# Altium schematic sheet EP40-FR-RR.SchDoc
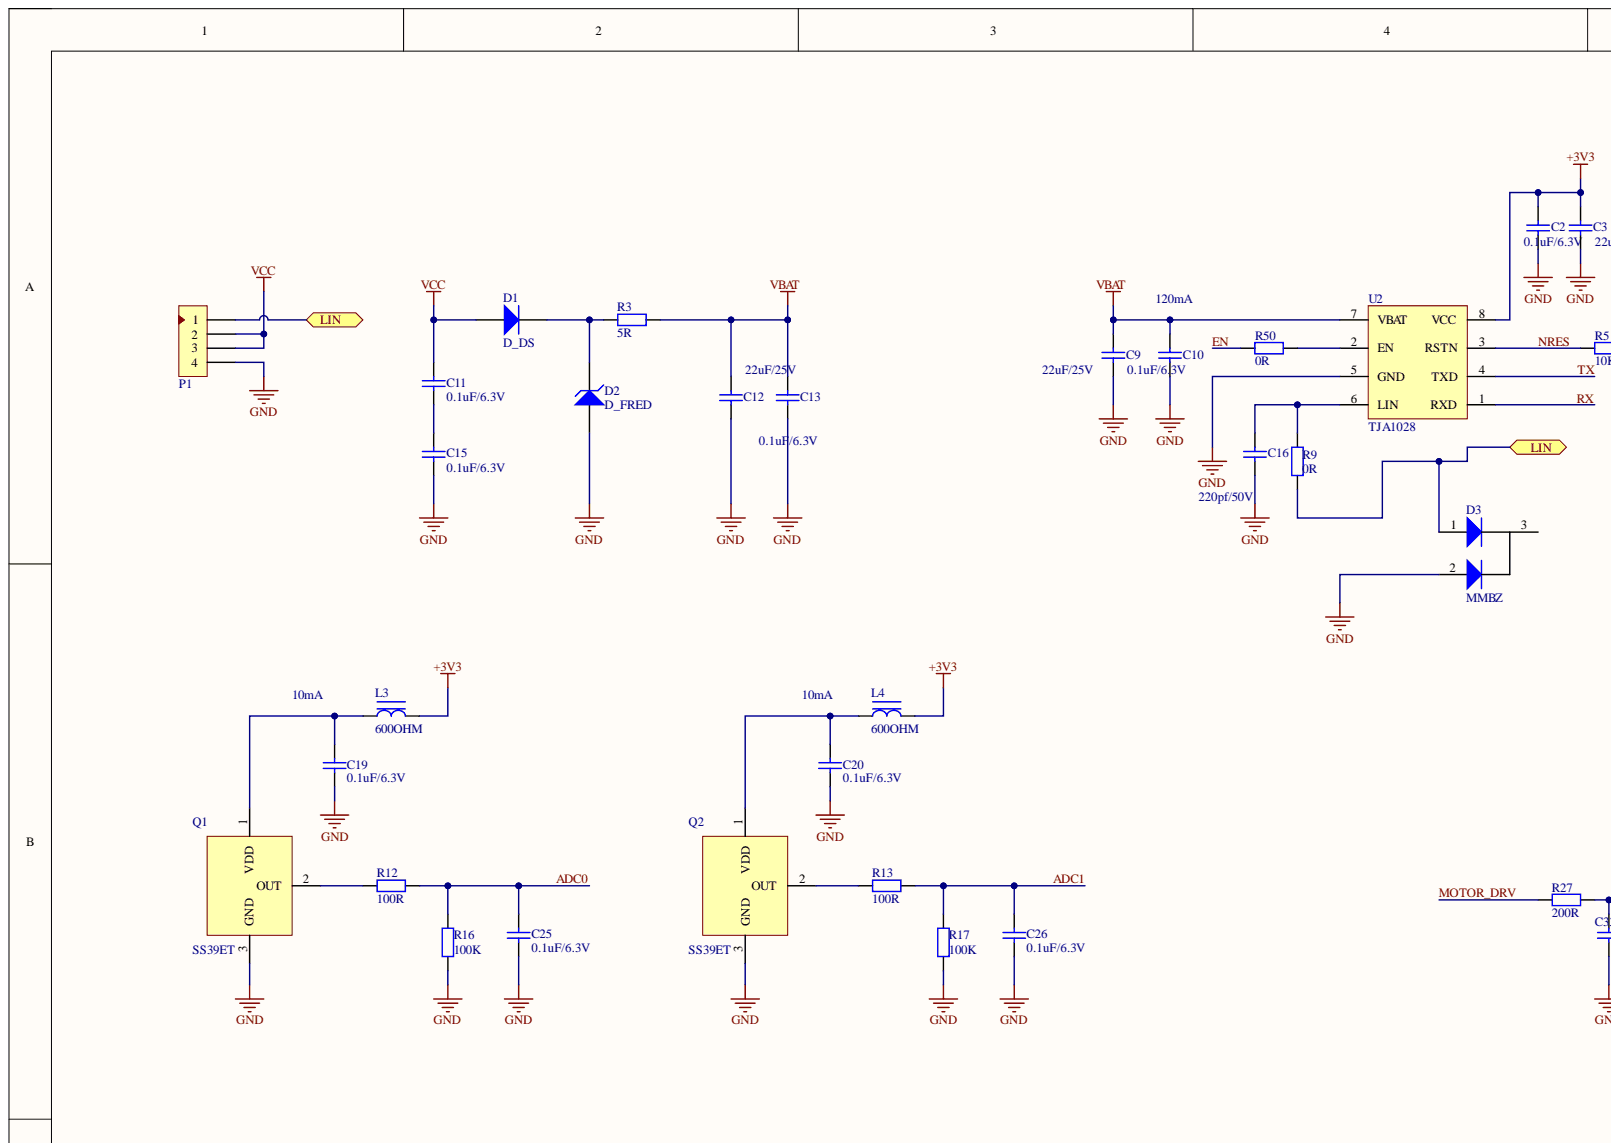
[diagram p0: EP40-FR-RR.SchDoc, top left]
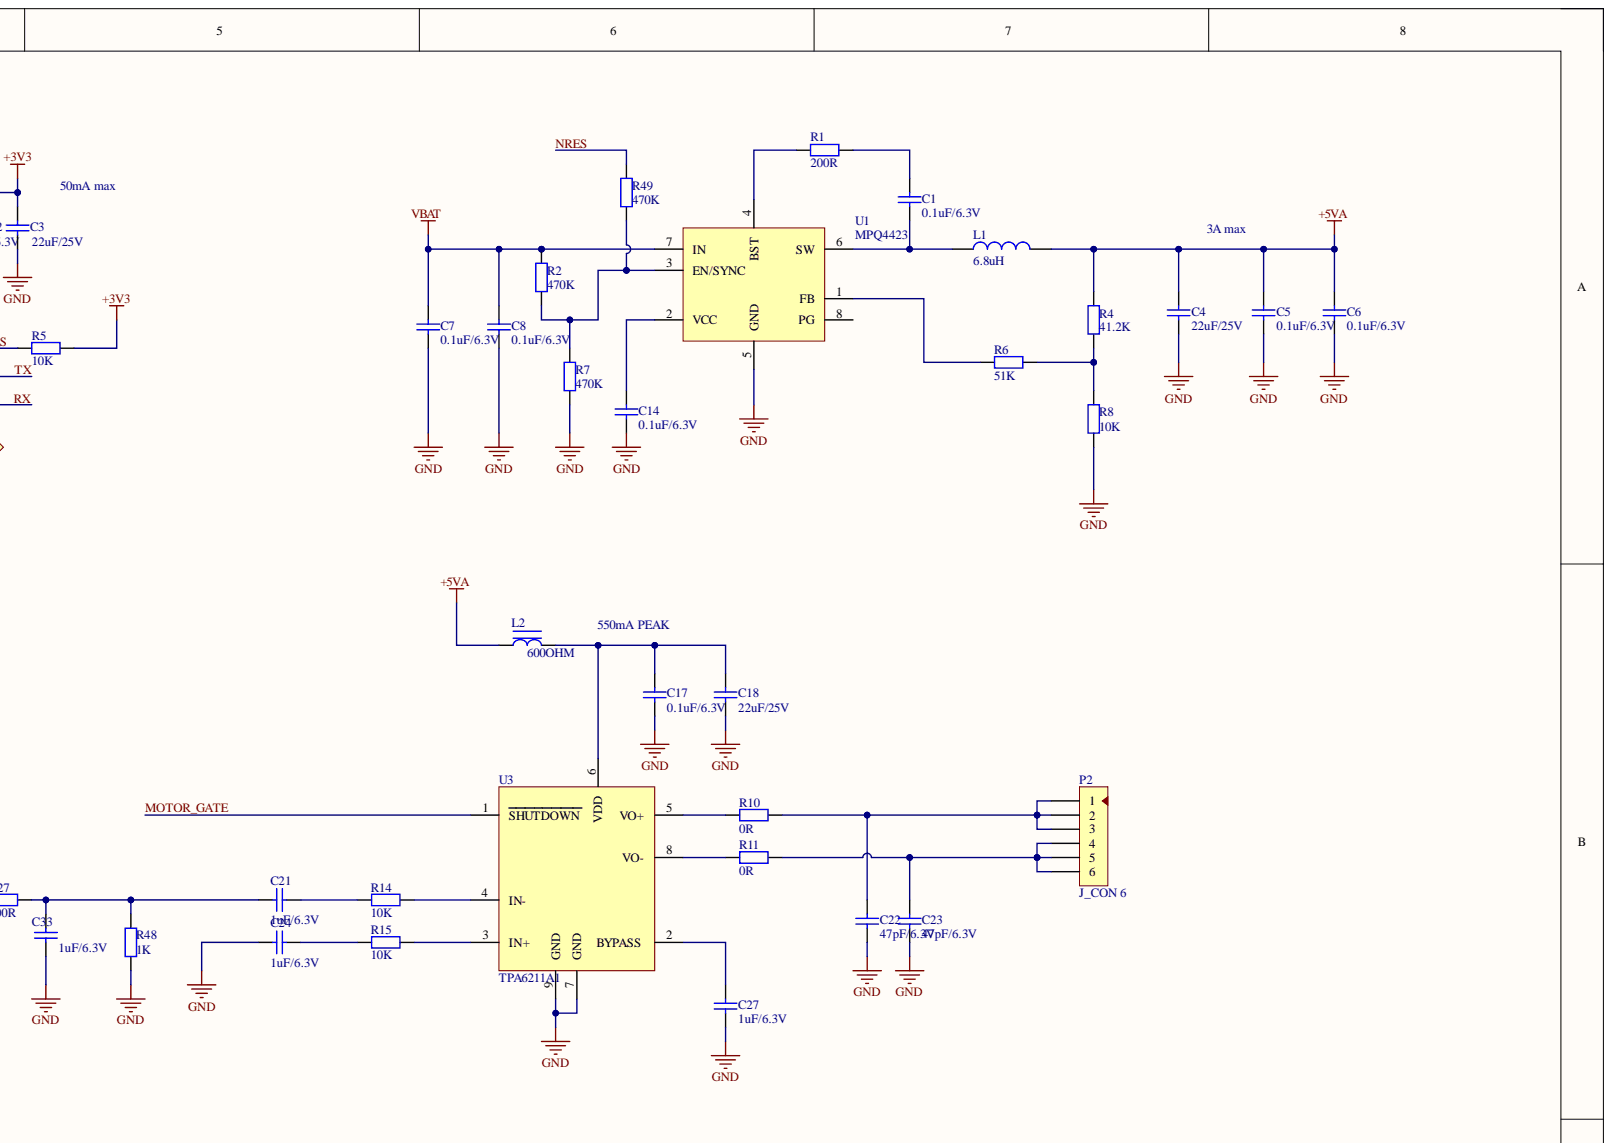
[diagram p1: EP40-FR-RR.SchDoc, top right]
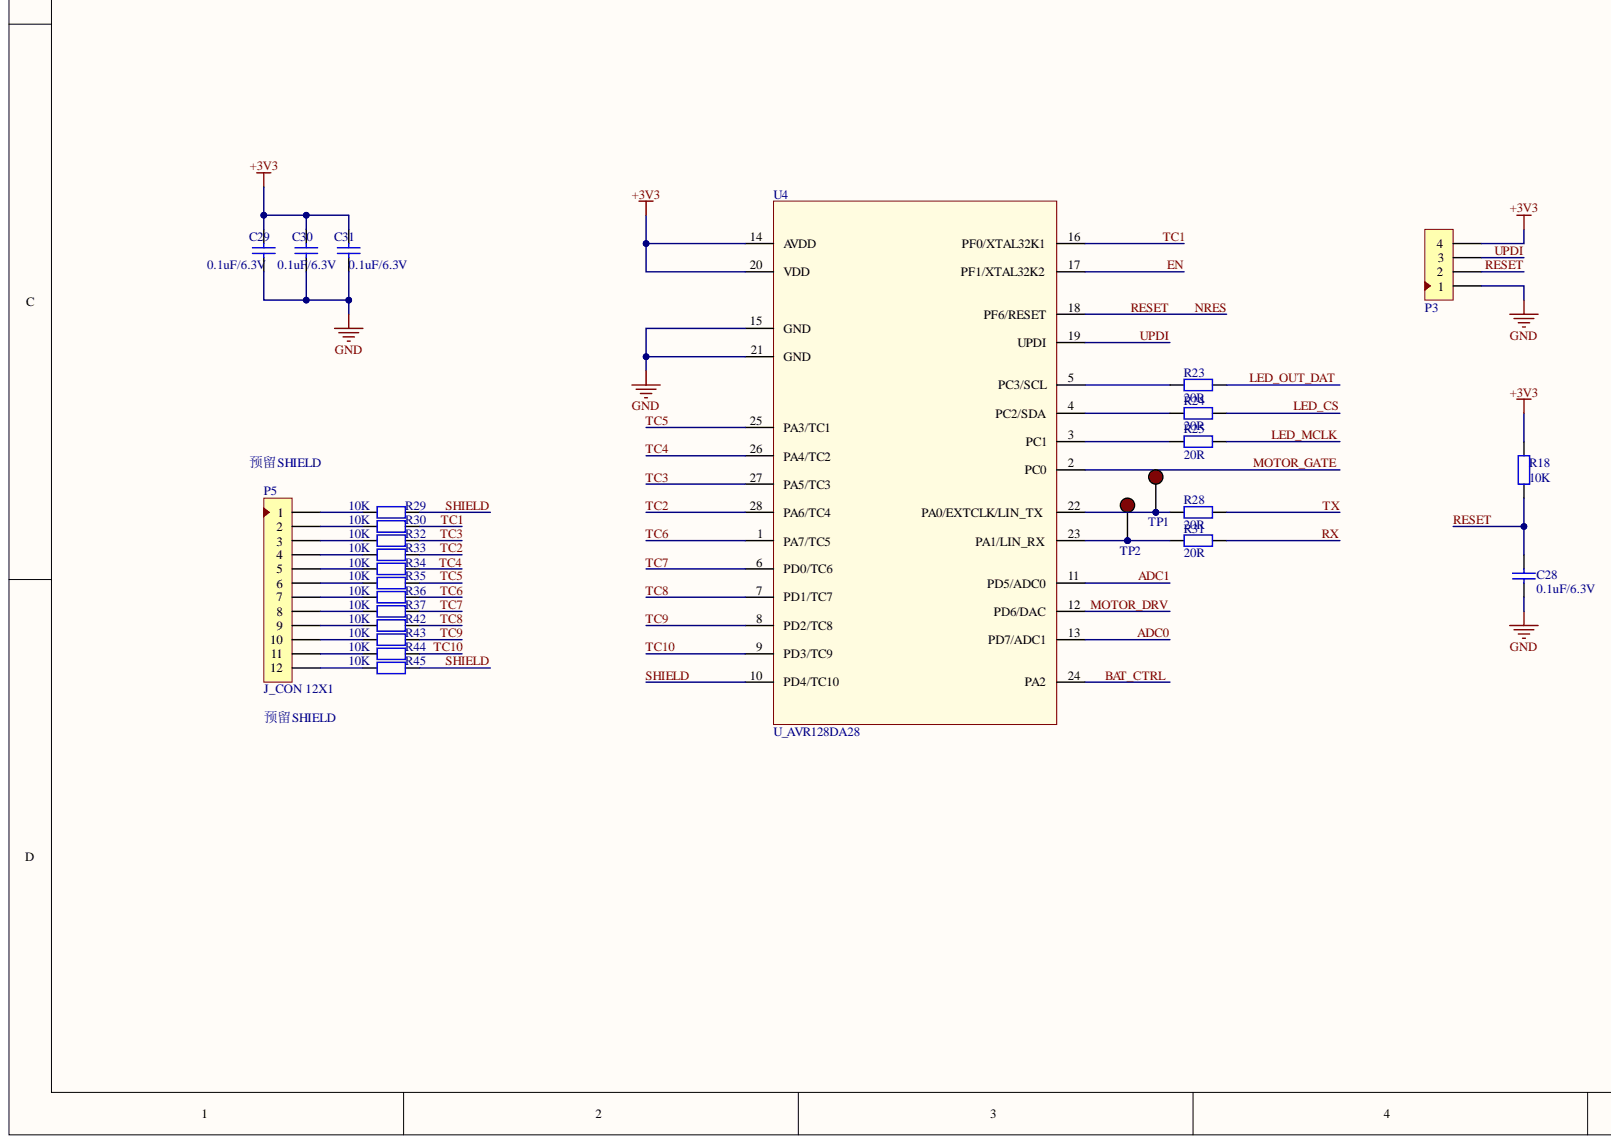
[diagram p2: EP40-FR-RR.SchDoc, bottom left]
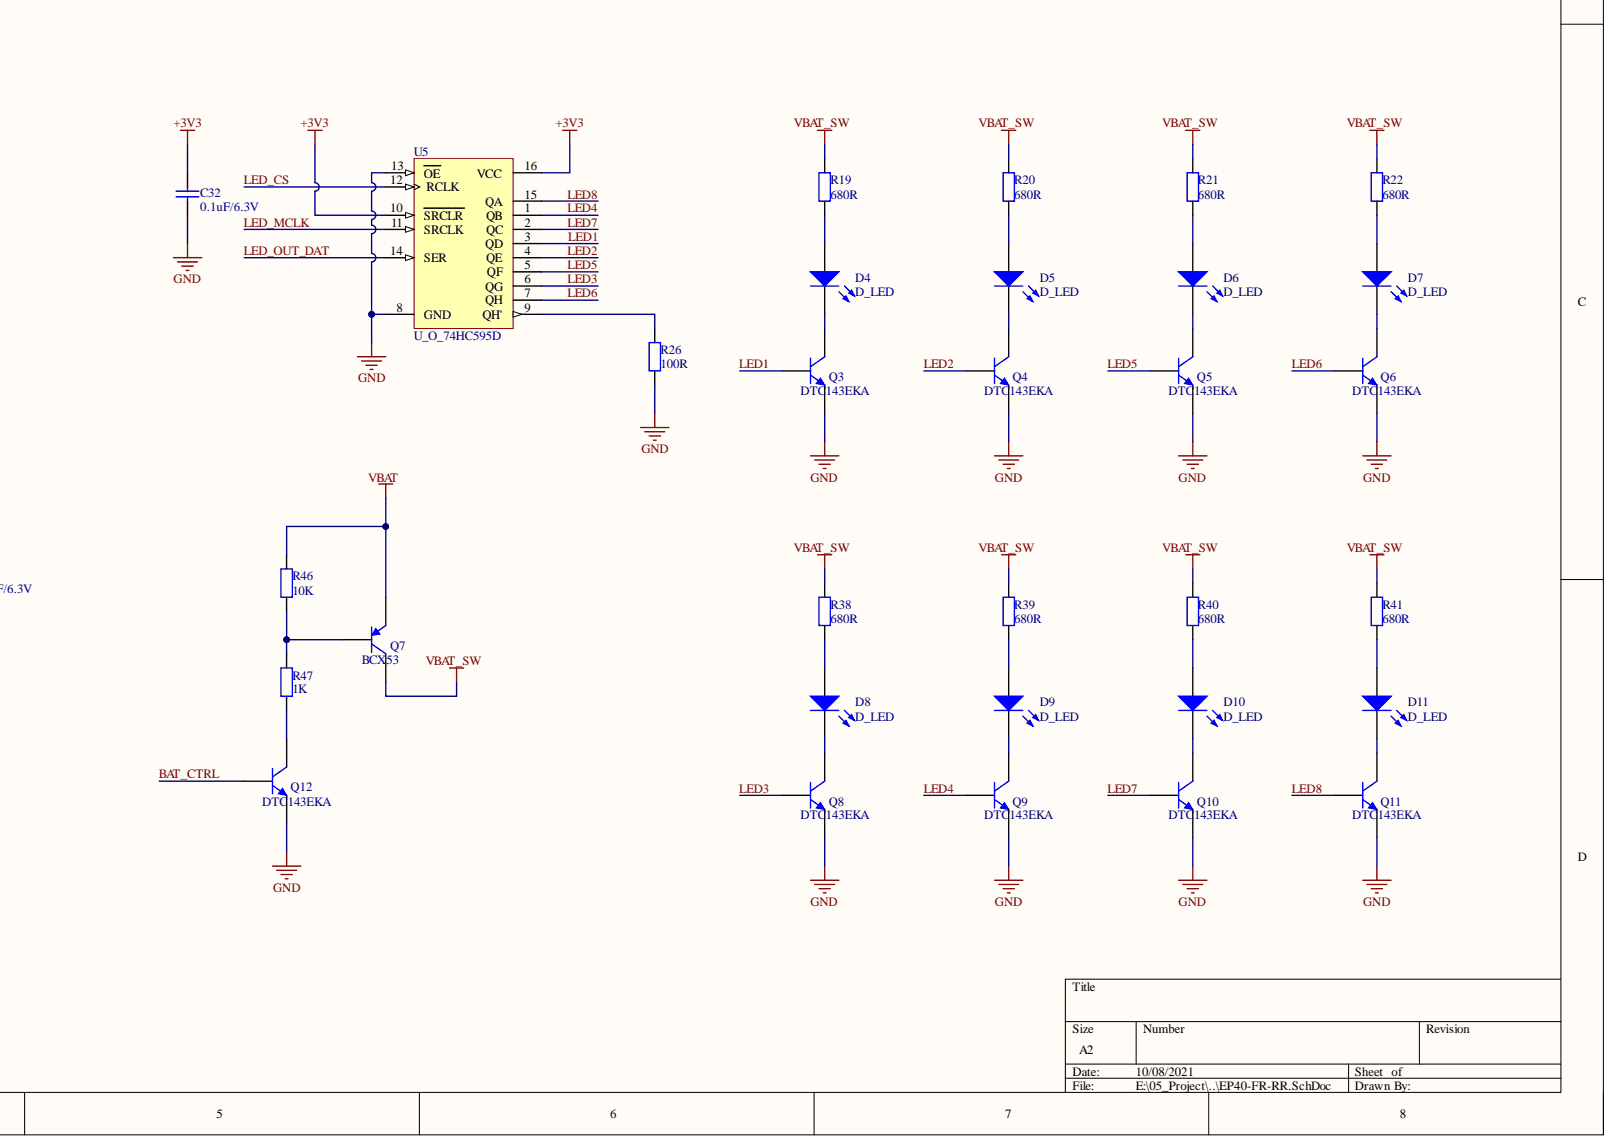
[diagram p3: EP40-FR-RR.SchDoc, bottom right]

## Parts
C1  0.1uF/6.3V  [C 0603_N]
C2  0.1uF/6.3V  [C 0603_N]
C3  22uF/25V  [C 1210_N]
C4  22uF/25V  [C 1210_N]
C5  0.1uF/6.3V  [C 0603_N]
C6  0.1uF/6.3V  [C 0603_N]
C7  0.1uF/6.3V  [C 0603_N]
C8  0.1uF/6.3V  [C 0603_N]
C9  22uF/25V  [C 1210_N]
C10  0.1uF/6.3V  [C 0603_N]
C11  0.1uF/6.3V  [C 0603_N]
C12  22uF/25V  [C 1210_N]
C13  0.1uF/6.3V  [C 0603_N]
C14  0.1uF/6.3V  [C 0603_N]
C15  0.1uF/6.3V  [C 0603_N]
C16  220pf/50V  [C 0603_N]
C17  0.1uF/6.3V  [C 0603_N]
C18  22uF/25V  [C 1206_N]
C19  0.1uF/6.3V  [C 0603_N]
C20  0.1uF/6.3V  [C 0603_N]
C21  1uF/6.3V  [C 0603_N]
C22  47pF/6.3V  [C 0603_N]
C23  47pF/6.3V  [C 0603_N]
C24  1uF/6.3V  [C 0603_N]
C25  0.1uF/6.3V  [C 0603_N]
C26  0.1uF/6.3V  [C 0603_N]
C27  1uF/6.3V  [C 0603_N]
C28  0.1uF/6.3V  [C 0603_N]
C29  0.1uF/6.3V  [C 0603_N]
C30  0.1uF/6.3V  [C 0603_N]
C31  0.1uF/6.3V  [C 0603_N]
C32  0.1uF/6.3V  [C 0603_N]
C33  1uF/6.3V  [C 0603_N]
D1  D_DS  [D SMA(DO-214AC)]
D2  D_FRED  [D SMC(DO-214AB)]
D3  MMBZ  [SOT23-3N]
D4  D_LED  [D LED-2214]
D5  D_LED  [D LED-2214]
D6  D_LED  [D LED-2214]
D7  D_LED  [D LED-2214]
D8  D_LED  [D LED-2214]
D9  D_LED  [D LED-2214]
D10  D_LED  [D LED-2214]
D11  D_LED  [D LED-2214]
L1  6.8uH  [L-SMD-0630]
L2  600OHM  [L 0805]
L3  600OHM  [L 0805]
L4  600OHM  [L 0805]
P1  J_CON 4  [EP40-FR-RR]  (value not drawn)
P2  J_CON 6  [FPC0.5 2H-WS-6P]
P3  J_CON 4  [EP40 - 2]  (value not drawn)
P5  J_CON 12X1  [FPC0.5 2H-WS-12P]
Q1  SS39ET  [SOT23-3N]
Q2  SS39ET  [SOT23-3N]
Q3  DTC143EKA  [SOT23-3L]
Q4  DTC143EKA  [SOT23-3L]
Q5  DTC143EKA  [SOT23-3L]
Q6  DTC143EKA  [SOT23-3L]
Q7  BCX53  [SOT89_N]
Q8  DTC143EKA  [SOT23-3L]
Q9  DTC143EKA  [SOT23-3L]
Q10  DTC143EKA  [SOT23-3L]
Q11  DTC143EKA  [SOT23-3L]
Q12  DTC143EKA  [SOT23-3L]
R1  200R  [R 0603_N]
R2  470K  [R 0603_N]
R3  5R  [R 2512_N]
R4  41.2K  [R 0603_N]
R5  10K  [R 0603_N]
R6  51K  [R 0603_N]
R7  470K  [R 0603_N]
R8  10K  [R 0603_N]
R9  0R  [R 0603_N]
R10  0R  [R 0603_N]
R11  0R  [R 0603_N]
R12  100R  [R 0603_N]
R13  100R  [R 0603_N]
R14  10K  [R 0603_N]
R15  10K  [R 0603_N]
R16  100K  [R 0603_N]
R17  100K  [R 0603_N]
R18  10K  [R 0603_N]
R19  680R  [R 0603_N]
R20  680R  [R 0603_N]
R21  680R  [R 0603_N]
R22  680R  [R 0603_N]
R23  20R  [R 0603_N]
R24  20R  [R 0603_N]
R25  20R  [R 0603_N]
R26  100R  [R 0603_N]
R27  200R  [R 0603_N]
R28  20R  [R 0603_N]
R29  10K  [R 0402_N]
R30  10K  [R 0402_N]
R31  20R  [R 0603_N]
R32  10K  [R 0402_N]
R33  10K  [R 0402_N]
R34  10K  [R 0402_N]
R35  10K  [R 0402_N]
R36  10K  [R 0402_N]
R37  10K  [R 0402_N]
R38  680R  [R 0603_N]
R39  680R  [R 0603_N]
R40  680R  [R 0603_N]
R41  680R  [R 0603_N]
R42  10K  [R 0402_N]
R43  10K  [R 0402_N]
R44  10K  [R 0402_N]
R45  10K  [R 0402_N]
R46  10K  [R 0603_N]
R47  1K  [R 0603_N]
R48  1K  [R 0603_N]
R49  470K  [R 0603_N]
R50  0R  [R 0603_N]
TP1  TP  [TEST1.5]
TP2  TP  [TEST1.5]
U1  MPQ4423  [QFN8 3X3 - 4423]
U2  TJA1028  [SOP8-DFN]
U3  TPA6211A1  [MSOP8_N - EP]
U4  U_AVR128DA28  [SSOP28_N]
U5  U_O_74HC595D  [SOP16_N]

## Ports
LIN

## Nets
+3V3: C2.2 C3.2 C29.2 C30.2 C31.2 C32.2 L3.2 L4.2 P3.4 R5.1 R18.2 U2.8(VCC) U4.14(AVDD) U4.20(VDD) U5.10(SRCLR) U5.16(VCC)
+5VA: C4.2 C5.2 C6.2 L1.2 L2.1 R4.2
GND: C2.1 C3.1 C4.1 C5.1 C6.1 C7.1 C8.1 C9.1 C10.1 C12.1 C13.1 C14.1 C15.1 C16.1 C17.1 C18.1 C19.1 C20.1 C22.2 C23.2 C24.2 C25.1 C26.1 C27.2 C28.1 C29.1 C30.1 C31.1 C32.1 C33.2 D2.1 D3.2 P1.4 P3.1 Q1.3 Q2.3 Q3.2 Q4.2 Q5.2 Q6.2 Q8.2 Q9.2 Q10.2 Q11.2 Q12.2 R7.1 R8.1 R16.2 R17.2 R26.1 R48.2 U1.5(GND) U2.5(GND) U3.7(GND) U3.9(GND) U4.15(GND) U4.21(GND) U5.8(GND) U5.13(OE)
VBAT: C7.2 C8.2 C9.2 C10.2 C12.2 C13.2 Q7.3 R2.2 R3.1 R46.2 U1.7(IN) U2.7(VBAT)
VBAT_SW: Q7.2 R19.2 R20.2 R21.2 R22.2 R38.2 R39.2 R40.2 R41.2
VCC: C11.2 D1.1 P1.2 P1.3
ADC0: C25.2 R12.1 R16.1 U4.13(PD7/ADC1)
ADC1: C26.2 R13.1 R17.1 U4.11(PD5/ADC0)
BAT_CTRL: Q12.1 U4.24(PA2)
EN: R50.2 U4.17(PF1/XTAL32K2)
LED1: Q3.1 U5.3(QD)
LED2: Q4.1 U5.4(QE)
LED3: Q8.1 U5.6(QG)
LED4: Q9.1 U5.1(QB)
LED5: Q5.1 U5.5(QF)
LED6: Q6.1 U5.7(QH)
LED7: Q10.1 U5.2(QC)
LED8: Q11.1 U5.15(QA)
LED_CS: R24.1 U5.12(RCLK)
LED_MCLK: R25.1 U5.11(SRCLK)
LED_OUT_DAT: R23.1 U5.14(SER)
LIN: D3.1 P1.1 R9.1 ; port LIN
MOTOR_DRV: R27.2 U4.12(PD6/DAC)
MOTOR_GATE: U3.1(SHUTDOWN) U4.2(PC0)
NRES: C28.2 P3.2 R5.2 R18.1 R49.2 U2.3(RSTN) U4.18(PF6/RESET)
RX: R31.1 U2.1(RXD)
SHIELD: R29.1 R45.1 U4.10(PD4/TC10)
TC1: R30.1 U4.16(PF0/XTAL32K1)
TC2: R33.1 U4.28(PA6/TC4)
TC3: R32.1 U4.27(PA5/TC3)
TC4: R34.1 U4.26(PA4/TC2)
TC5: R35.1 U4.25(PA3/TC1)
TC6: R36.1 U4.1(PA7/TC5)
TC7: R37.1 U4.6(PD0/TC6)
TC8: R42.1 U4.7(PD1/TC7)
TC9: R43.1 U4.8(PD2/TC8)
TC10: R44.1 U4.9(PD3/TC9)
TX: R28.1 U2.4(TXD)
UPDI: P3.3 U4.19(UPDI)
NetC1_1: C1.1 L1.1 U1.6(SW)
NetC1_2: C1.2 R1.1
NetC11_1: C11.1 C15.2
NetC14_2: C14.2 U1.2(VCC)
NetC16_2: C16.2 R9.2 U2.6(LIN)
NetC17_2: C17.2 C18.2 L2.2 U3.6(VDD)
NetC19_2: C19.2 L3.1 Q1.1
NetC20_2: C20.2 L4.1 Q2.1
NetC21_1: C21.1 R14.2
NetC21_2: C21.2 C33.1 R27.1 R48.1
NetC22_1: C22.1 P2.1 P2.2 P2.3 R10.1
NetC23_1: C23.1 P2.4 P2.5 P2.6 R11.1
NetC24_1: C24.1 R15.2
NetC27_1: C27.1 U3.2(BYPASS)
NetD1_2: D1.2 D2.2 R3.2
NetD4_1: D4.1 R19.1
NetD4_2: D4.2 Q3.3
NetD5_1: D5.1 R20.1
NetD5_2: D5.2 Q4.3
NetD6_1: D6.1 R21.1
NetD6_2: D6.2 Q5.3
NetD7_1: D7.1 R22.1
NetD7_2: D7.2 Q6.3
NetD8_1: D8.1 R38.1
NetD8_2: D8.2 Q8.3
NetD9_1: D9.1 R39.1
NetD9_2: D9.2 Q9.3
NetD10_1: D10.1 R40.1
NetD10_2: D10.2 Q10.3
NetD11_1: D11.1 R41.1
NetD11_2: D11.2 Q11.3
NetP5_1: P5.1 R29.2
NetP5_2: P5.2 R30.2
NetP5_3: P5.3 R32.2
NetP5_4: P5.4 R33.2
NetP5_5: P5.5 R34.2
NetP5_6: P5.6 R35.2
NetP5_7: P5.7 R36.2
NetP5_8: P5.8 R37.2
NetP5_9: P5.9 R42.2
NetP5_10: P5.10 R43.2
NetP5_11: P5.11 R44.2
NetP5_12: P5.12 R45.2
NetQ1_2: Q1.2 R12.2
NetQ2_2: Q2.2 R13.2
NetQ7_1: Q7.1 R46.1 R47.2
NetQ12_3: Q12.3 R47.1
NetR1_2: R1.2 U1.4(BST)
NetR2_1: R2.1 R7.2 R49.1 U1.3(EN/SYNC)
NetR4_1: R4.1 R6.1 R8.2
NetR6_2: R6.2 U1.1(FB)
NetR10_2: R10.2 U3.5(VO+)
NetR11_2: R11.2 U3.8(VO-)
NetR14_1: R14.1 U3.4(IN-)
NetR15_1: R15.1 U3.3(IN+)
NetR23_2: R23.2 U4.5(PC3/SCL)
NetR24_2: R24.2 U4.4(PC2/SDA)
NetR25_2: R25.2 U4.3(PC1)
NetR26_2: R26.2 U5.9(QH')
NetR28_2: R28.2 TP1.1 U4.22(PA0/EXTCLK/LIN_TX)
NetR31_2: R31.2 TP2.1 U4.23(PA1/LIN_RX)
NetR50_1: R50.1 U2.2(EN)

Not connected: D3 3; U1 8(PG)

## Library data of the parts
C1-C33 = C_CAP: 贴片电容; Component Kind: Standard; Library Name: 01_Basic.SchLib
D1 = D_DS: 整流/开关二极管; Component Kind: Standard; Library Name: 01_Basic.SchLib
D2 = D_FRED: 超快恢复二极管; Component Kind: Standard; Library Name: 01_Basic.SchLib
D3 = D_DDA1: 共阴极双开关二极管; Component Kind: Standard; Library Name: 01_Basic.SchLib
D4-D11 = D_LED: 发光二极管; Component Kind: Standard; Library Name: 01_Basic.SchLib
L1 = L_IND: 电感; Component Kind: Standard; Library Name: 01_Basic.SchLib
L2-L4 = L_IRON: 功率电感; Component Kind: Standard; Library Name: 01_Basic.SchLib
P1 P3 = J_CON 4: 接插件; Component Kind: Standard; LatestRevisionDate: 17-Jul-2002; LatestRevisionNote: Re-released for DXP Platform.; Library Name: 02_Ports.SchLib; Publisher: Altium Limited
P2 = J_CON 6: 接插件; Component Kind: Standard; LatestRevisionDate: 17-Jul-2002; LatestRevisionNote: Re-released for DXP Platform.; Library Name: 02_Ports.SchLib; Publisher: Altium Limited
P5 = J_CON 12: 接插件; Component Kind: Standard; Library Name: 02_Ports.SchLib
Q1 Q2 = Q_SS39ET: Component Kind: Standard; Library Name: 01_Basic.SchLib
Q3-Q6 Q8-Q12 = Q_NPN: NPN型三极管; Component Kind: Standard; Library Name: 01_Basic.SchLib
Q7 = Q_PNP: PNP型三极管; Component Kind: Standard; Library Name: 01_Basic.SchLib
R1-R50 = R_RES: 贴片电阻; Component Kind: Standard; Library Name: 01_Basic.SchLib
TP1 TP2 = TP: 测试点; Component Kind: Standard; Library Name: 01_Basic.SchLib
U3 = U_TPA6211: Component Kind: Standard; Library Name: 03_IC.SchLib
U5 = U_O_74HC595D: 74系列逻辑芯片; Component Kind: Standard; Library Name: 03_IC.SchLib
U1 = MPQ4423: Component Kind: Standard; Library Name: 03_IC.SchLib
U2 = TJA1028: Component Kind: Standard; Library Name: 03_IC.SchLib
U4 = U_AVR128DA28: Component Kind: Standard; Library Name: 03_IC.SchLib
